# Altium schematic sheet Sheet1.SchDoc
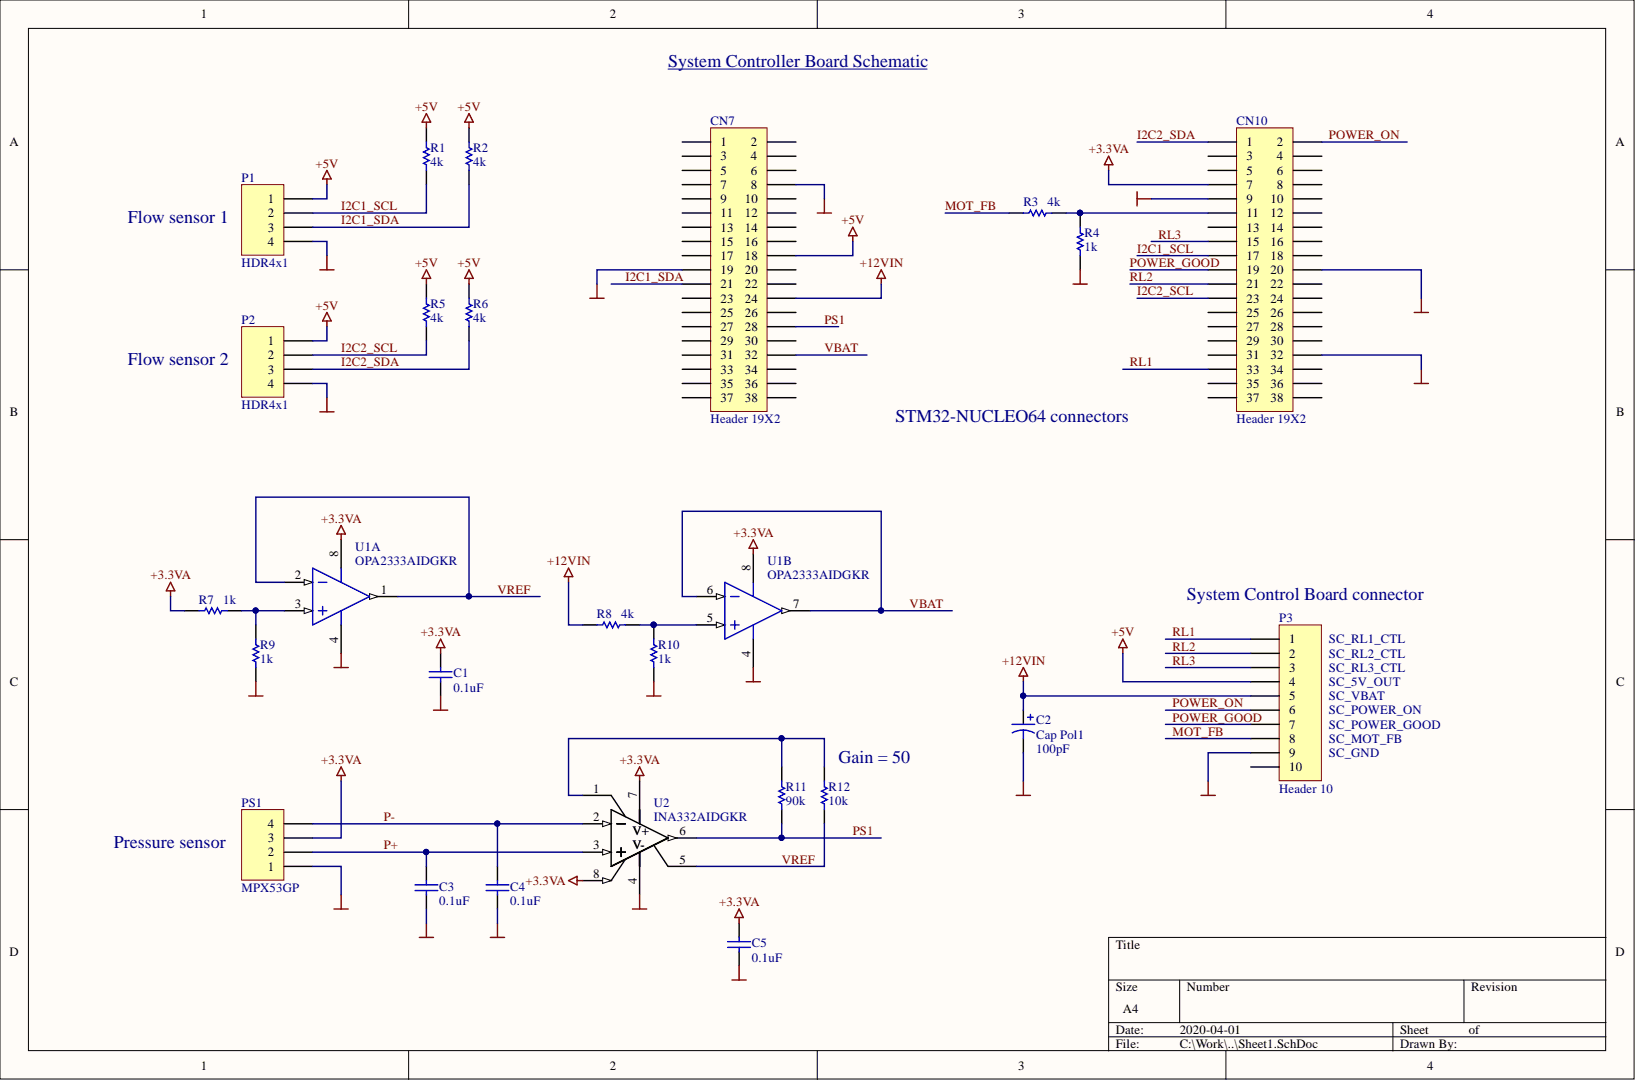
[diagram p0: Sheet1.SchDoc]

## Parts
C1  0.1uF  [0402]
C2  Cap Pol1  value 100pF  [RB7.6-15]
C3  0.1uF  [0402]
C4  0.1uF  [0402]
C5  0.1uF  [0402]
CN7  Header 19X2  [HDR2X30]
CN10  Header 19X2  [HDR2X30]
P1  HDR4x1  [HDR1X4]
P2  HDR4x1  [HDR1X4]
P3  Header 10  [HDR1X10]
PS1  MPX53GP  [HDR1X4]
R1  4k  [AXIAL-0.3]
R2  4k  [AXIAL-0.3]
R3  4k  [AXIAL-0.3]
R4  1k  [AXIAL-0.3]
R5  4k  [AXIAL-0.3]
R6  4k  [AXIAL-0.3]
R7  1k  [AXIAL-0.3]
R8  4k  [AXIAL-0.3]
R9  1k  [AXIAL-0.3]
R10  1k  [AXIAL-0.3]
R11  value 90k  [AXIAL-0.3]  (hidden comment: 1k)
R12  value 10k  [AXIAL-0.3]  (hidden comment: 1k)
U1  OPA2333AIDGKR  [DGK0008A_M]  (parts A,B)
U2  INA332AIDGKR  [DGK0008A_N]

## Nets
+3.3VA: C1.2 C5.2 CN10.7 PS1.3 R7.1 U1.8 U2.7(V+) U2.8
+5V: CN7.18 P1.1 P2.1 P3.4 R1.2 R2.2 R5.2 R6.2
+12VIN: C2.1 CN7.24 P3.5 R8.1
GND: C1.1 C2.2 C3.1 C4.1 C5.1 CN7.8 CN7.19 CN10.9 CN10.20 CN10.32 P1.4 P2.4 P3.9 PS1.1 R4.1 R9.1 R10.1 U1.4 U2.4(V-)
I2C1_SCL: CN10.17 P1.2 R1.1
I2C1_SDA: CN7.21 P1.3 R2.1
I2C2_SCL: CN10.23 P2.2 R5.1
I2C2_SDA: CN10.1 P2.3 R6.1
MOT_FB: P3.8 R3.1
P+: C3.2 PS1.2 U2.3
P-: C4.2 PS1.4 U2.2
POWER_GOOD: CN10.19 P3.7
POWER_ON: CN10.2 P3.6
PS1: CN7.28 R11.1 U2.6
RL1: CN10.33 P3.1
RL2: CN10.21 P3.2
RL3: CN10.15 P3.3
VBAT: CN7.32 U1.6 U1.7
VREF: R12.1 U1.1 U1.2 U2.5
NetCN10_11: CN10.11 R3.2 R4.2
NetR7_2: R7.2 R9.2 U1.3
NetR8_2: R8.2 R10.2 U1.5
NetR11_2: R11.2 R12.2 U2.1

Not connected: CN7 1 2 3 4 5 6 7 9 10 11 12 13 14 15 16 17 20 22 23 25 26 27 29 30 31 33 34 35 36 37 38; CN10 3 4 5 6 8 10 12 13 14 16 18 22 24 25 26 27 28 29 30 31 34 35 36 37 38; P3 10

## Library data of the parts
C1 C3-C5 = Cap Semi: CAP CER 0.1UF 25V 10% X7R 0402; PART NUMBER: C1005X7R1E104K050BB; Component Kind: Standard; LatestRevisionDate: 29-May-2009; LatestRevisionNote: IPC-7351 Footprint Added.; Library Name: Miscellaneous Devices.IntLib; PackageDescription: Chip Capacitor; PackageReference: C0402; Published: 8-Jun-2000; Simulation: CAP; Value: 0.1uF; Publisher: Altium Limited
C2 = Cap Pol1: Polarized Capacitor (Radial); Component Kind: Standard; LatestRevisionDate: 17-Jul-2002; LatestRevisionNote: Re-released for DXP Platform.; Library Name: Miscellaneous Devices.IntLib; PackageDescription: Polarized Capacitor (Radial); 2 Leads; PackageReference: RB7.6-15; Published: 8-Jun-2000; Simulation: CAP; Publisher: Altium Limited
CN7 CN10 = Header 19X2: Header, 30-Pin, Dual row; Component Kind: Standard; LatestRevisionDate: 17-Jul-2002; LatestRevisionNote: Re-released for DXP Platform.; Library Name: Schlib1.SchLib; Publisher: Altium Limited
P1 P2 PS1 = Header 4: Header, 4-Pin; Component Kind: Standard; LatestRevisionDate: 17-Jul-2002; LatestRevisionNote: Re-released for DXP Platform.; Library Name: Miscellaneous Connectors.IntLib; Publisher: Altium Limited
P3 = Header 10: Header, 10-Pin; Component Kind: Standard; LatestRevisionDate: 17-Jul-2002; LatestRevisionNote: Re-released for DXP Platform.; Library Name: Miscellaneous Connectors.IntLib; Publisher: Altium Limited
R1-R12 = Res1: Resistor; Component Kind: Standard; LatestRevisionDate: 17-Jul-2002; LatestRevisionNote: Re-released for DXP Platform.; Library Name: Miscellaneous Devices.IntLib; PackageDescription: Axial Device, Thru-Hole; 2 Leads; 0.3 in Pin Spacing; PackageReference: AXIAL-0.3; Published: 8-Jun-2000; Simulation: RESISTOR; Publisher: Altium Limited
  R1-R3 R5 R6 R8: Value: 4k
  R4 R7 R9 R10: Value: 1k
U1 = CMP-0272-01196-3: 17 uA, MicroPower, Precision, Zero Drift CMOS Operational Amplifier, 1.8 to 5.5 V, -40 to 125 degC, 8-pin SOP (DGK8), Green (RoHS & no Sb/Br); Manufacturer: Texas Instruments; CMRR(Min)(dB): 106; IIB(Max)(pA): 200; Iq per channel(Max)(mA): 0.025; Number of Channels: 2; Offset Drift(Typ)(uV/C): 0.02; Slew Rate(Typ)(V/us): 0.16; Sub Family: Low Offset Voltage Amplifier (<=500uV), Low Power Amp (<=500uA), Low Supply Voltage Amplifier (<=2.7V), Precision Amplifier, Zero Drift Precision Amplifier; Total Supply Voltage(Max)(+5V is 5, +/-5V is 10): 5.5; Total Supply Voltage(Min)(+5V is 5, +/-5V is 10): 1.8; Vio (25C)(Max)(mV): 0.01; Code_JEDEC: MO-187; Component Kind: Standard; ComponentLink1Description: Manufacturer URL; ComponentLink1URL: http://www.ti.com/; ComponentLink2Description: Datasheet; ComponentLink2URL: http://www.ti.com/general/docs/lit/getliterature.tsp?genericPartNumber=OPA2333&fileType=pdf; ComponentLink3Description: Package Specification; ComponentLink3URL: http://www.ti.com/litv/pdf/mpds028d; DatasheetVersion: SBOS351C; Eco-Plan: Green (RoHS & no Sb/Br); Library Name: Altium Content Vault; Mounting Technology: Surface Mount; PackageDescription: 8-Pin Thin Small Outline, Body 3 x 3 mm, Pitch 0.65 mm; PackageReference: DGK0008A; PackageVersion: 4073329/E, 05/2006; PartNumber: OPA2333AIDGKR
U2 = INA332AIDGKR: No Description Available; Manufacturer: Texas Instruments; Component Kind: Standard; Library Name: Schlib1.SchLib; PartNumber: INA332AIDGKR; RefDes: RefDes; Type: DEV; Value: Value; Copyright: Copyright (C) 2018 Accelerated Designs. All rights reserved
